# Altium schematic sheet Measurement.SchDoc(Measurement)
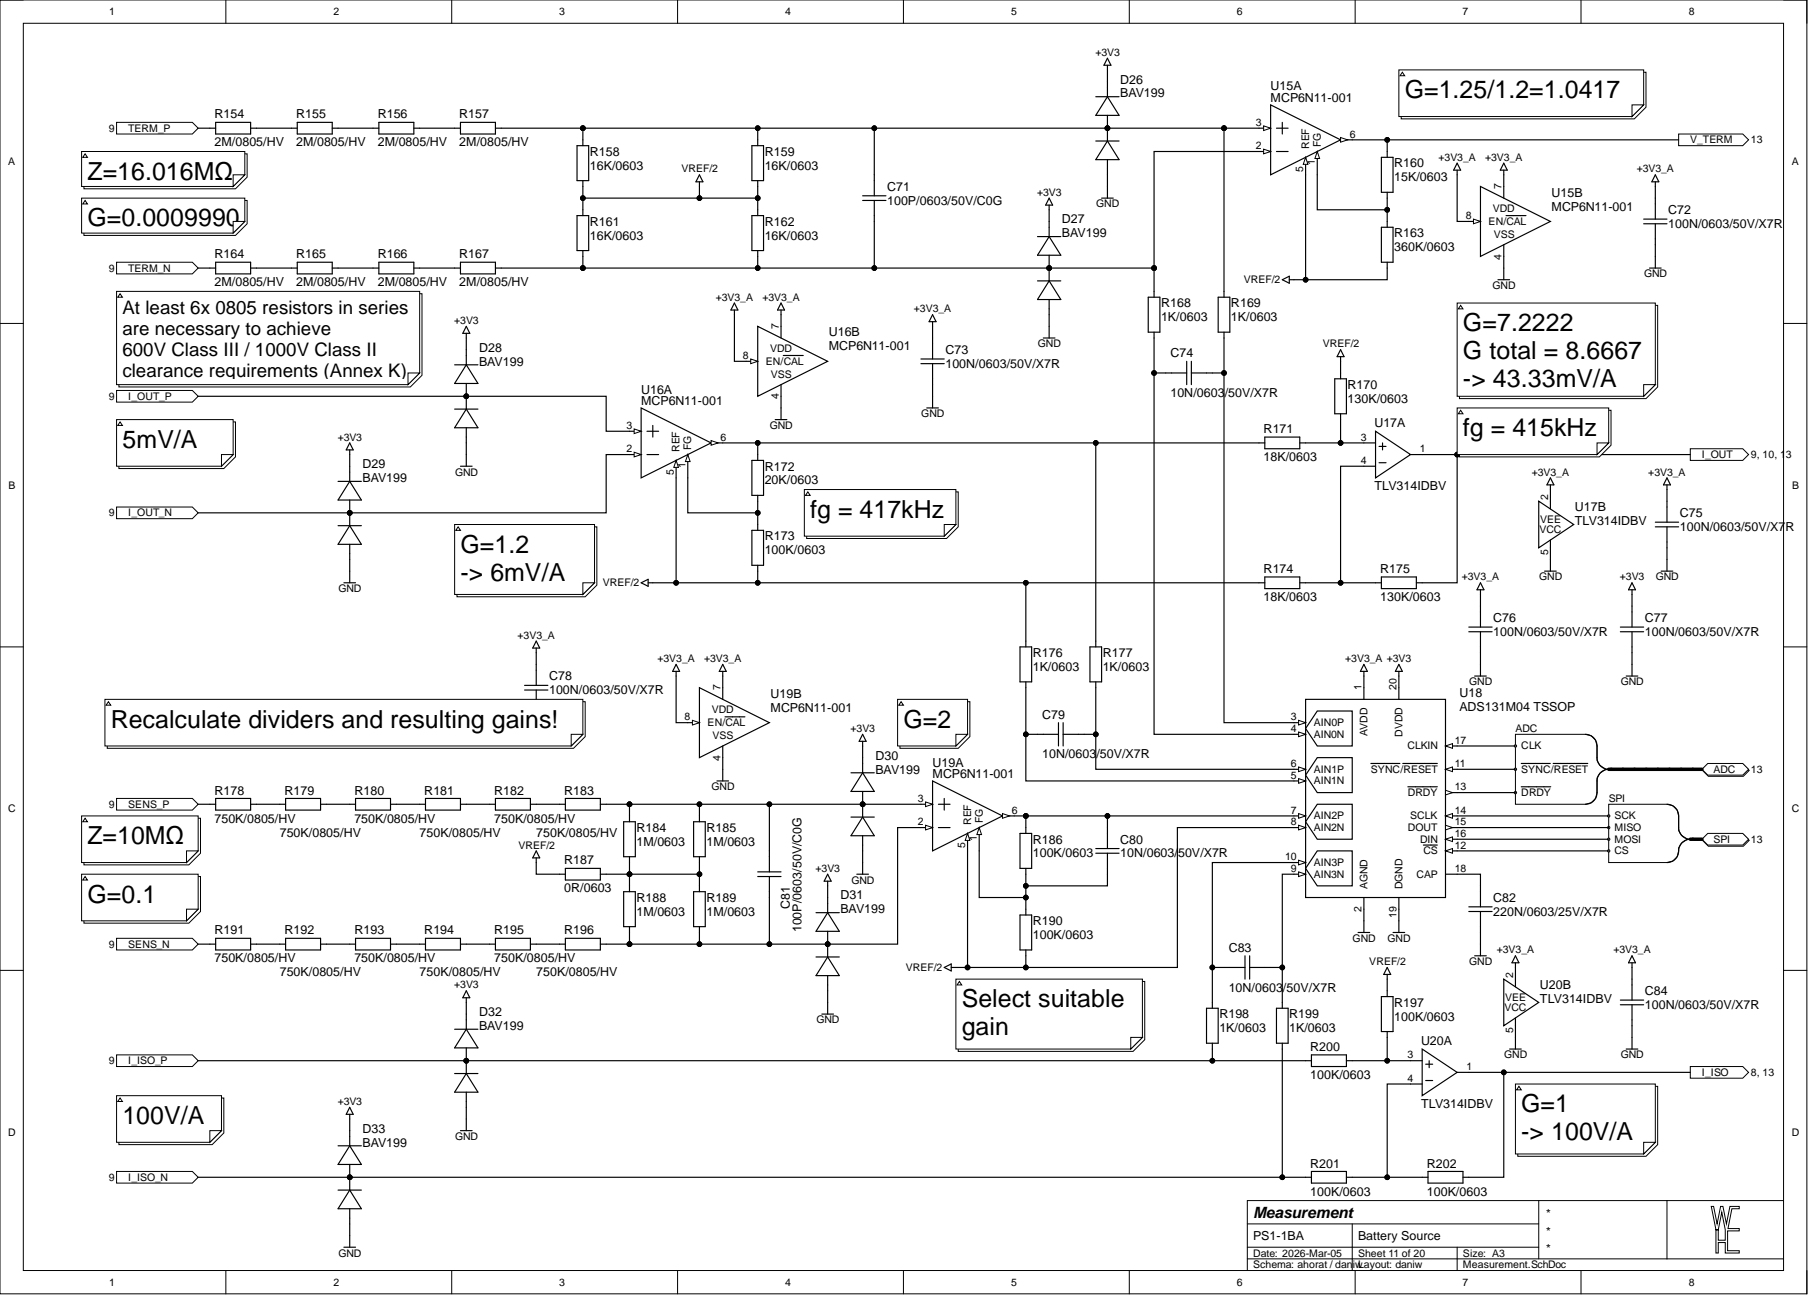
[diagram p0: Measurement.SchDoc]

## Parts
C71  100P/0603/50V/C0G  [CAP0603_C0G]
C72  100N/0603/50V/X7R  [CAP0603_X7R]
C73  100N/0603/50V/X7R  [CAP0603_X7R]
C74  10N/0603/50V/X7R  [CAP0603_X7R]
C75  100N/0603/50V/X7R  [CAP0603_X7R]
C76  100N/0603/50V/X7R  [CAP0603_X7R]
C77  100N/0603/50V/X7R  [CAP0603_X7R]
C78  100N/0603/50V/X7R  [CAP0603_X7R]
C79  10N/0603/50V/X7R  [CAP0603_X7R]
C80  10N/0603/50V/X7R  [CAP0603_X7R]
C81  100P/0603/50V/C0G  [CAP0603_C0G]
C82  220N/0603/25V/X7R  [CAP0603_X7R]
C83  10N/0603/50V/X7R  [CAP0603_X7R]
C84  100N/0603/50V/X7R  [CAP0603_X7R]
D26  BAV199  [SOT2395P280X125-3-SE]
D27  BAV199  [SOT2395P280X125-3-SE]
D28  BAV199  [SOT2395P280X125-3-SE]
D29  BAV199  [SOT2395P280X125-3-SE]
D30  BAV199  [SOT2395P280X125-3-SE]
D31  BAV199  [SOT2395P280X125-3-SE]
D32  BAV199  [SOT2395P280X125-3-SE]
D33  BAV199  [SOT2395P280X125-3-SE]
R154  2M/0805/HV  [R0805-0.50-HV-WW]
R155  2M/0805/HV  [R0805-0.50-HV-WW]
R156  2M/0805/HV  [R0805-0.50-HV-WW]
R157  2M/0805/HV  [R0805-0.50-HV-WW]
R158  16K/0603  [R0603-0.40-WW]
R159  16K/0603  [R0603-0.40-WW]
R160  15K/0603  [R0603-0.40-WW]
R161  16K/0603  [R0603-0.40-WW]
R162  16K/0603  [R0603-0.40-WW]
R163  360K/0603  [R0603-0.40-WW]
R164  2M/0805/HV  Package: 0805  [R0805-0.50-HV-WW]
R165  2M/0805/HV  [R0805-0.50-HV-WW]
R166  2M/0805/HV  [R0805-0.50-HV-WW]
R167  2M/0805/HV  [R0805-0.50-HV-WW]
R168  1K/0603  [R0603-0.40-WW]
R169  1K/0603  [R0603-0.40-WW]
R170  130K/0603  [R0603-0.40-WW]
R171  18K/0603  [R0603-0.40-WW]
R172  20K/0603  [R0603-0.40-WW]
R173  100K/0603  [R0603-0.40-WW]
R174  18K/0603  [R0603-0.40-WW]
R175  130K/0603  [R0603-0.40-WW]
R176  1K/0603  [R0603-0.40-WW]
R177  1K/0603  [R0603-0.40-WW]
R178  750K/0805/HV  [R0805-0.50-HV-WW]
R179  750K/0805/HV  [R0805-0.50-HV-WW]
R180  750K/0805/HV  [R0805-0.50-HV-WW]
R181  750K/0805/HV  [R0805-0.50-HV-WW]
R182  750K/0805/HV  [R0805-0.50-HV-WW]
R183  750K/0805/HV  [R0805-0.50-HV-WW]
R184  1M/0603  [R0603-0.40-WW]
R185  1M/0603  [R0603-0.40-WW]
R186  100K/0603  [R0603-0.40-WW]
R187  0R/0603  [R0603-0.40-WW]
R188  1M/0603  [R0603-0.40-WW]
R189  1M/0603  [R0603-0.40-WW]
R190  100K/0603  [R0603-0.40-WW]
R191  750K/0805/HV  [R0805-0.50-HV-WW]
R192  750K/0805/HV  [R0805-0.50-HV-WW]
R193  750K/0805/HV  [R0805-0.50-HV-WW]
R194  750K/0805/HV  [R0805-0.50-HV-WW]
R195  750K/0805/HV  [R0805-0.50-HV-WW]
R196  750K/0805/HV  [R0805-0.50-HV-WW]
R197  100K/0603  [R0603-0.40-WW]
R198  1K/0603  [R0603-0.40-WW]
R199  1K/0603  [R0603-0.40-WW]
R200  100K/0603  [R0603-0.40-WW]
R201  100K/0603  [R0603-0.40-WW]
R202  100K/0603  [R0603-0.40-WW]
U15  MCP6N11-001  [SOP127P600X155-8-SW]  (parts A,B)
U16  MCP6N11-001  [SOP127P600X155-8-SW]  (parts A,B)
U17  TLV314IDBV  [SOT2395P280X125-5-NW]  (parts A,B)
U18  ADS131M04 TSSOP  [SOP065P640X120-20NW]
U18
U19  MCP6N11-001  [SOP127P600X155-8-SW]  (parts A,B)
U20  TLV314IDBV  [SOT2395P280X125-5-NW]  (parts A,B)

## Ports
ADC, ADC.CLK, ADC.~{DRDY}, ADC.~{SYNC}/~{RESET}, I_ISO, I_ISO_N, I_ISO_P, I_OUT, I_OUT_N, I_OUT_P, SENS_N, SENS_P, SPI, SPI.CS, SPI.MISO, SPI.MOSI, SPI.SCK, TERM_N, TERM_P, V_TERM

## Nets
+3V3: C77.1 D26.2 D27.2 D28.2 D29.2 D30.2 D31.2 D32.2 D33.2 U18.20
+3V3_A: C72.1 C73.1 C75.1 C76.1 C78.1 C84.1 U15.7 U15.8(EN/CAL) U16.7 U16.8(EN/CAL) U17.2 U18.1 U19.7 U19.8(EN/CAL) U20.2
GND: C72.2 C73.2 C75.2 C76.2 C77.2 C78.2 C82.2 C84.2 D26.1 D27.1 D28.1 D29.1 D30.1 D31.1 D32.1 D33.1 U15.4 U16.4 U17.5 U18.2 U18.19 U19.4 U20.5
VREF/2: R158.2 R159.2 R161.1 R162.1 R163.2 R170.2 R173.2 R174.1 R176.1 R187.2 R190.2 R197.2 U15.5(REF) U16.5(REF) U18.8 U19.5(REF)
I_ISO: R202.2 U20.1 ; port I_ISO
I_ISO_N: D33.3 R199.2 R201.1 ; port I_ISO_N
I_ISO_P: D32.3 R198.2 R200.1 ; port I_ISO_P
I_OUT: R175.2 U17.1 ; port I_OUT
I_OUT_N: D29.3 U16.2 ; port I_OUT_N
I_OUT_P: D28.3 U16.3 ; port I_OUT_P
SENS_N: R191.1 ; port SENS_N
SENS_P: R178.1 ; port SENS_P
TERM_N: R164.1 ; port TERM_N
TERM_P: R154.1 ; port TERM_P
V_TERM: R160.1 U15.6 ; port V_TERM
NetC71_1: C71.1 D26.3 R157.1 R158.1 R159.1 R169.1 U15.3
NetC71_2: C71.2 D27.3 R161.2 R162.2 R167.2 R168.1 U15.2
NetC74_1: C74.1 R168.2 U18.4
NetC74_2: C74.2 R169.2 U18.3
NetC79_1: C79.1 R176.2 U18.5
NetC79_2: C79.2 R177.2 U18.6
NetC80_1: C80.1 R186.1 U18.7 U19.6
NetC80_2: C80.2 R186.2 R190.1 U19.1(FG)
NetC81_1: C81.1 D30.3 R183.2 R184.1 R185.1 U19.3
NetC81_2: C81.2 D31.3 R188.2 R189.2 R196.2 U19.2
NetC82_1: C82.1 U18.18
NetC83_1: C83.1 R198.1 U18.10
NetC83_2: C83.2 R199.1 U18.9
NetR154_2: R154.2 R155.2
NetR155_1: R155.1 R156.1
NetR156_2: R156.2 R157.2
NetR160_2: R160.2 R163.1 U15.1(FG)
NetR164_2: R164.2 R165.1
NetR165_2: R165.2 R166.1
NetR166_2: R166.2 R167.1
NetR170_1: R170.1 R171.2 U17.3
NetR171_1: R171.1 R172.1 R177.1 U16.6
NetR172_2: R172.2 R173.1 U16.1(FG)
NetR174_2: R174.2 R175.1 U17.4
NetR178_2: R178.2 R179.1
NetR179_2: R179.2 R180.1
NetR180_2: R180.2 R181.1
NetR181_2: R181.2 R182.1
NetR182_2: R182.2 R183.1
NetR184_2: R184.2 R185.2 R187.1 R188.1 R189.1
NetR191_2: R191.2 R192.1
NetR192_2: R192.2 R193.1
NetR193_2: R193.2 R194.1
NetR194_2: R194.2 R195.1
NetR195_2: R195.2 R196.1
NetR197_1: R197.1 R200.2 U20.3
NetR201_2: R201.2 R202.1 U20.4
NetU18_11: U18.11 ; port ADC
NetU18_12: U18.12 ; port SPI
NetU18_13: U18.13 ; port ADC
NetU18_14: U18.14 ; port SPI
NetU18_15: U18.15 ; port SPI
NetU18_16: U18.16 ; port SPI
NetU18_17: U18.17 ; port ADC

## Library data of the parts
C71 C81 = 100P/0603/50V/C0G: CAP SM 0603 100pF 50V C0G; Manufacturer: GENERIC; Manufacturer 1: YAGEO; Manufacturer Part Number 1: CC0603JRNPO9BN101; Absolute Value: 1E-10; Database Table Name: C$; Eurocircuits GPN: GPC0603101-C0G; Component Kind: Standard; HelpURL: https://www.yageo.com/upload/media/product/productsearch/datasheet/mlcc/UPY-GP_NP0_16V-to-50V_18.pdf; JLC Type: Basic; Manufacturer 2: YAGEO; Manufacturer Part Number 2: CC0603KRNPO9BN101; Manufacturer Part Number 3: CL10C101JB8NNNC; Package: 0603; Part Number: 100P/0603/50V/C0G; Supplier 1: Digi-Key; Supplier 2: Digi-Key; Supplier 3: LCSC; Supplier Part Number 1: 311-1069-1-ND; Supplier Part Number 2: 311-3600-1-ND; Supplier Part Number 3: C14858; Value: 100pF; Library Name: daniw_altium_lib.DbLib
C72 C73 C75-C78 C84 = 100N/0603/50V/X7R: CAP SM 0603 100nF 50V X7R; Manufacturer: GENERIC; Manufacturer 1: YAGEO; Manufacturer Part Number 1: CC0603KPX7R9BB104; Absolute Value: 1E-7; Database Table Name: C$; Eurocircuits GPN: GPC0603104; Component Kind: Standard; HelpURL: https://www.yageo.com/upload/media/product/app/datasheet/mlcc/upy-gphc_x7r_6_3v-to-250v.pdf; JLC Type: Basic; Manufacturer 2: YAGEO; Manufacturer Part Number 2: CC0603MRX7R9BB104; Manufacturer Part Number 3: CC0603KRX7R9BB104; Package: 0603; Part Number: 100N/0603/50V/X7R; Supplier 1: Digi-Key; Supplier 2: Digi-Key; Supplier 3: LCSC; Supplier Part Number 1: 311-3567-1-ND; Supplier Part Number 2: 311-4110-1-ND; Supplier Part Number 3: C14663; Value: 100nF; Library Name: daniw_altium_lib.DbLib
C74 C79 C80 C83 = 10N/0603/50V/X7R: CAP SM 0603 10nF 50V X7R; Manufacturer: GENERIC; Manufacturer 1: YAGEO; Manufacturer Part Number 1: CC0603KPX7R9BB103; Absolute Value: 1E-8; Database Table Name: C$; Eurocircuits GPN: GPC0603103; Component Kind: Standard; HelpURL: https://www.yageo.com/upload/media/product/app/datasheet/mlcc/upy-gphc_x7r_6_3v-to-250v.pdf; Manufacturer 2: YAGEO; Manufacturer Part Number 2: CC0603KRX7R9BB103; Manufacturer Part Number 3: CC0603KRX7R9BB103; Package: 0603; Part Number: 10N/0603/50V/X7R; Supplier 1: Digi-Key; Supplier 2: Digi-Key; Supplier 3: LCSC; Supplier Part Number 1: 311-1572-1-ND; Supplier Part Number 2: 311-1085-1-ND; Supplier Part Number 3: C100042; Value: 10nF; Library Name: daniw_altium_lib.DbLib
C82 = 220N/0603/25V/X7R: CAP SM 0603 220nF 25V X7R; Manufacturer: GENERIC; Absolute Value: 2.2E-7; Database Table Name: C$; Eurocircuits GPN: GPC0603; Component Kind: Standard; JLC Type: Basic; Manufacturer Part Number 3: CL10B224KA8NNNC; Package: 0603; Part Number: 220N/0603/25V/X7R; Supplier 3: LCSC; Supplier Part Number 3: C21120; Value: 220nF; Library Name: daniw_altium_lib.DbLib
D26-D33 = BAV199: Silicon Low Leakage Diode 85V; Manufacturer: Infineon Technologies; Manufacturer Part Number 1: BAV199E6433HTMA1; Database Table Name: D$; Absolute Value: 85V; Component Kind: Standard; HelpURL: https://www.infineon.com/dgdl/bav199series.pdf?folderId=db3a30431400ef6801141c748874044e&fileId=db3a30431400ef6801141cba733104e5; Manufacturer 1: Infineon Technologies; Manufacturer 3: LRC; Manufacturer Part Number 3: LBAV199LT1G; Package: SOT23; Part Number: BAV199; Supplier 1: Digikey; Supplier 3: LCSC; Supplier Part Number 1: BAV199E6433HTMA1CT-ND; Supplier Part Number 3: C12740; Value: BAV199; Library Name: daniw_altium_lib.DbLib
R154-R157 R164-R167 = 2M/0805/HV: RES SM 0805 2M High voltage; Manufacturer: GENERIC; Manufacturer 1: YAGEO; Manufacturer Part Number 1: RV0805FR-072ML; Absolute Value: 2000000; Database Table Name: R$; Component Kind: Standard; HelpURL: https://www.yageogroup.com/content/datasheet/asset/file/PYU-RV_51_ROHS_L; JLC Type: Extended; Manufacturer 3: YAGEO; Manufacturer Part Number 3: RV0805FR-072ML; Part Number: 2M/0805/HV; Supplier 1: Digi-Key; Supplier 3: LCSC; Supplier Part Number 1: 13-RV0805FR-072MLCT-ND; Supplier Part Number 3: C873372; Value: 2M; Library Name: daniw_altium_lib.DbLib
  R154-R157 R165-R167: Package: 0805
R158 R159 R161 R162 = 16K/0603: RES SM 0603 16K; Manufacturer: GENERIC; Manufacturer 1: YAGEO; Manufacturer Part Number 1: RC0603FR-1316KL; Absolute Value: 16000; Database Table Name: R$; Eurocircuits GPN: GPR060316K; Component Kind: Standard; HelpURL: https://www.yageogroup.com/content/datasheet/asset/file/PYU-RC_GROUP_51_ROHS_L; JLC Type: Extended; Manufacturer 2: YAGEO; Manufacturer 3: YAGEO; Manufacturer Part Number 2: RC0603FR-1016KL; Manufacturer Part Number 3: RC0603FR-0716KL; Package: 0603; Part Number: 16K/0603; Supplier 1: Digi-Key; Supplier 2: Digi-Key; Supplier 3: LCSC; Supplier Part Number 1: 13-RC0603FR-1316KLCT-ND; Supplier Part Number 2: 13-RC0603FR-1016KLCT-ND; Supplier Part Number 3: C137788; Value: 16K; Library Name: daniw_altium_lib.DbLib
R160 = 15K/0603: RES SM 0603 15K; Manufacturer: GENERIC; Manufacturer 1: YAGEO; Manufacturer Part Number 1: RC0603FR-1315KL; Absolute Value: 15000; Database Table Name: R$; Eurocircuits GPN: GPR060315K; Component Kind: Standard; HelpURL: https://www.yageogroup.com/content/datasheet/asset/file/PYU-RC_GROUP_51_ROHS_L; JLC Type: Basic; Manufacturer 2: YAGEO; Manufacturer 3: UNI-ROYAL(Uniroyal Elec); Manufacturer Part Number 2: RC0603FR-1015KL; Manufacturer Part Number 3: 0603WAF1502T5E; Package: 0603; Part Number: 15K/0603; Supplier 1: Digi-Key; Supplier 2: Digi-Key; Supplier 3: LCSC; Supplier Part Number 1: 13-RC0603FR-1315KLCT-ND; Supplier Part Number 2: 13-RC0603FR-1015KLCT-ND; Supplier Part Number 3: C22809; Value: 15K; Library Name: daniw_altium_lib.DbLib
R163 = 360K/0603: RES SM 0603 360K; Manufacturer: GENERIC; Manufacturer 1: YAGEO; Manufacturer Part Number 1: RC0603FR-07360KL; Absolute Value: 360000; Database Table Name: R$; Eurocircuits GPN: GPR0603360K; Component Kind: Standard; HelpURL: https://www.yageogroup.com/content/datasheet/asset/file/PYU-RC_GROUP_51_ROHS_L; JLC Type: Extended; Manufacturer 3: UNI-ROYAL(Uniroyal Elec); Manufacturer Part Number 3: 0603WAF3603T5E; Package: 0603; Part Number: 360K/0603; Supplier 1: Digi-Key; Supplier 3: LCSC; Supplier Part Number 1: 311-360KHRCT-ND; Supplier Part Number 3: C23146; Value: 360K; Library Name: daniw_altium_lib.DbLib
R168 R169 R176 R177 R198 R199 = 1K/0603: RES SM 0603 1K; Manufacturer: GENERIC; Manufacturer 1: YAGEO; Manufacturer Part Number 1: RC0603FR-131KL; Absolute Value: 1000; Database Table Name: R$; Eurocircuits GPN: GPR06031K; Component Kind: Standard; HelpURL: https://www.yageogroup.com/content/datasheet/asset/file/PYU-RC_GROUP_51_ROHS_L; JLC Type: Basic; Manufacturer 2: YAGEO; Manufacturer 3: UNI-ROYAL(Uniroyal Elec); Manufacturer Part Number 2: RC0603FR-101KL; Manufacturer Part Number 3: 0603WAF1001T5E; Package: 0603; Part Number: 1K/0603; Supplier 1: Digi-Key; Supplier 2: Digi-Key; Supplier 3: LCSC; Supplier Part Number 1: 13-RC0603FR-131KLCT-ND; Supplier Part Number 2: 13-RC0603FR-101KLCT-ND; Supplier Part Number 3: C21190; Value: 1K; Library Name: daniw_altium_lib.DbLib
R170 R175 = 130K/0603: RES SM 0603 130K; Manufacturer: GENERIC; Manufacturer 1: YAGEO; Manufacturer Part Number 1: RC0603FR-07130KL; Absolute Value: 130000; Database Table Name: R$; Eurocircuits GPN: GPR0603130K; Component Kind: Standard; HelpURL: https://www.yageogroup.com/content/datasheet/asset/file/PYU-RC_GROUP_51_ROHS_L; JLC Type: Extended; Manufacturer 3: YAGEO; Manufacturer Part Number 3: RC0603FR-07130KL; Package: 0603; Part Number: 130K/0603; Supplier 1: Digi-Key; Supplier 3: LCSC; Supplier Part Number 1: 311-130KHRCT-ND; Supplier Part Number 3: C163432; Value: 130K; Library Name: daniw_altium_lib.DbLib
R171 R174 = 18K/0603: RES SM 0603 18K; Manufacturer: GENERIC; Manufacturer 1: YAGEO; Manufacturer Part Number 1: RC0603FR-1318KL; Absolute Value: 18000; Database Table Name: R$; Eurocircuits GPN: GPR060318K; Component Kind: Standard; HelpURL: https://www.yageogroup.com/content/datasheet/asset/file/PYU-RC_GROUP_51_ROHS_L; JLC Type: Basic; Manufacturer 2: YAGEO; Manufacturer 3: UNI-ROYAL; Manufacturer Part Number 2: RC0603FR-1018KL; Manufacturer Part Number 3: 0603WAF1802T5E; Package: 0603; Part Number: 18K/0603; Supplier 1: Digi-Key; Supplier 2: Digi-Key; Supplier 3: LCSC; Supplier Part Number 1: 13-RC0603FR-1318KLCT-ND; Supplier Part Number 2: 13-RC0603FR-1018KLCT-ND; Supplier Part Number 3: C25810; Value: 18K; Library Name: daniw_altium_lib.DbLib
R172 = 20K/0603: RES SM 0603 20K; Manufacturer: GENERIC; Manufacturer 1: YAGEO; Manufacturer Part Number 1: RC0603FR-1320KL; Absolute Value: 20000; Database Table Name: R$; Eurocircuits GPN: GPR060320K; Component Kind: Standard; HelpURL: https://www.yageogroup.com/content/datasheet/asset/file/PYU-RC_GROUP_51_ROHS_L; JLC Type: Basic; Manufacturer 2: YAGEO; Manufacturer 3: UNI-ROYAL(Uniroyal Elec); Manufacturer Part Number 2: RC0603FR-1020KL; Manufacturer Part Number 3: 0603WAF2002T5E; Package: 0603; Part Number: 20K/0603; Supplier 1: Digi-Key; Supplier 2: Digi-Key; Supplier 3: LCSC; Supplier Part Number 1: 13-RC0603FR-1320KLCT-ND; Supplier Part Number 2: 13-RC0603FR-1020KLCT-ND; Supplier Part Number 3: C4184; Value: 20K; Library Name: daniw_altium_lib.DbLib
R173 R186 R190 R197 R200-R202 = 100K/0603: RES SM 0603 100K; Manufacturer: GENERIC; Manufacturer 1: YAGEO; Manufacturer Part Number 1: RC0603FR-13100KL; Absolute Value: 100000; Database Table Name: R$; Eurocircuits GPN: GPR0603100K; Component Kind: Standard; HelpURL: https://www.yageogroup.com/content/datasheet/asset/file/PYU-RC_GROUP_51_ROHS_L; JLC Type: Basic; Manufacturer 2: YAGEO; Manufacturer 3: UNI-ROYAL(Uniroyal Elec); Manufacturer Part Number 2: RC0603FR-10100KL; Manufacturer Part Number 3: 0603WAF1003T5E; Package: 0603; Part Number: 100K/0603; Supplier 1: Digi-Key; Supplier 2: Digi-Key; Supplier 3: LCSC; Supplier Part Number 1: 13-RC0603FR-13100KLCT-ND; Supplier Part Number 2: 13-RC0603FR-10100KLCT-ND; Supplier Part Number 3: C25803; Value: 100K; Library Name: daniw_altium_lib.DbLib
R178-R183 R191-R196 = 750K/0805/HV: RES SM 0805 750K High voltage; Manufacturer: GENERIC; Manufacturer 1: YAGEO; Absolute Value: 750000; Database Table Name: R$; Component Kind: Standard; HelpURL: https://www.yageogroup.com/content/datasheet/asset/file/PYU-RV_51_ROHS_L; JLC Type: Extended; Manufacturer 3: YAGEO; Manufacturer Part Number 3: RV0805FR-07750KL; Package: 0805; Part Number: 750K/0805/HV; Supplier 3: LCSC; Supplier Part Number 3: C873432; Value: 750K; Library Name: daniw_altium_lib.DbLib
R184 R185 R188 R189 = 1M/0603: RES SM 0603 1M; Manufacturer: GENERIC; Manufacturer 1: YAGEO; Manufacturer Part Number 1: RC0603FR-131ML; Absolute Value: 1000000; Database Table Name: R$; Eurocircuits GPN: GPR06031M; Component Kind: Standard; HelpURL: https://www.yageogroup.com/content/datasheet/asset/file/PYU-RC_GROUP_51_ROHS_L; JLC Type: Basic; Manufacturer 2: YAGEO; Manufacturer 3: UNI-ROYAL(Uniroyal Elec); Manufacturer Part Number 2: RC0603FR-101ML; Manufacturer Part Number 3: 0603WAF1004T5E; Package: 0603; Part Number: 1M/0603; Supplier 1: Digi-Key; Supplier 2: Digi-Key; Supplier 3: LCSC; Supplier Part Number 1: 13-RC0603FR-131MLCT-ND; Supplier Part Number 2: 13-RC0603FR-101MLCT-ND; Supplier Part Number 3: C22935; Value: 1M; Library Name: daniw_altium_lib.DbLib
R187 = 0R/0603: RES SM 0603 0R; Manufacturer: GENERIC; Manufacturer 1: YAGEO; Manufacturer Part Number 1: RC0603FR-070RL; Absolute Value: 0; Database Table Name: R$; Eurocircuits GPN: GPR06030R; Component Kind: Standard; HelpURL: https://www.yageogroup.com/content/datasheet/asset/file/PYU-RC_GROUP_51_ROHS_L; JLC Type: Basic; Manufacturer 2: YAGEO; Manufacturer 3: UNI-ROYAL(Uniroyal Elec); Manufacturer Part Number 2: RC0603FR-130RL; Manufacturer Part Number 3: 0603WAF0000T5E; Package: 0603; Part Number: 0R/0603; Supplier 1: Digi-Key; Supplier 2: Digi-Key; Supplier 3: LCSC; Supplier Part Number 1: 311-0.0HRCT-ND; Supplier Part Number 2: 13-RC0603FR-130RLCT-ND; Supplier Part Number 3: C21189; Value: 0R; Library Name: daniw_altium_lib.DbLib
U15 U16 U19 = MCP6N11-001 SO-8: MCP6N11-001 500 kHz, 800 μA Instrumentation Amplifier SO-8; Manufacturer: Microchip Technology; Manufacturer Part Number 1: MCP6N11-001E/SN; Database Table Name: Integrated$; Component Kind: Standard; HelpURL: https://ww1.microchip.com/downloads/en/DeviceDoc/25073A.pdf; Manufacturer 1: Microchip Technology; Manufacturer 2: Microchip Technology; Manufacturer 3: Microchip Tech; Manufacturer Part Number 2: MCP6N11T-001E/SN; Manufacturer Part Number 3: MCP6N11T-001E/SN; Package: SO-8; Part Number: MCP6N11-001 SO-8; Supplier 1: Digi-Key; Supplier 2: Digi-Key; Supplier 3: LCSC; Supplier Part Number 1: MCP6N11-001E/SN-ND; Supplier Part Number 2: MCP6N11T-001E/SN-ND; Supplier Part Number 3: C623787; Library Name: daniw_altium_lib.DbLib
U17 U20 = TLV314 SOT23: TLV314, 3-MHz, Low-Power, Internal EMI Filter, RRIO, Operational Amplifier; Manufacturer: Texas Instruments; Manufacturer Part Number 1: TLV314IDBVR; Database Table Name: Integrated$; Component Kind: Standard; HelpURL: https://www.ti.com/lit/ds/symlink/tlv4314.pdf; Manufacturer 1: Texas Instruments; Manufacturer 2: Texas Instruments; Manufacturer 3: Texas Instruments; Manufacturer Part Number 2: TLV314IDBVT; Manufacturer Part Number 3: TLV314IDBVR; Package: SOT23-5; Part Number: TLV314 SOT23; Supplier 1: Digi-Key; Supplier 2: Digi-Key; Supplier 3: LCSC; Supplier Part Number 1: 296-46275-1-ND; Supplier Part Number 2: 296-44110-2-ND; Supplier Part Number 3: C133032; Library Name: daniw_altium_lib.DbLib
U18 = ADS131M04 TSSOP: ADS131M04 4-Channel, Simultaneously-Sampling, 24-Bit, Delta-Sigma ADC; Manufacturer: Texas Instruments; Manufacturer Part Number 1: ADS131M04IPWR; Database Table Name: Integrated$; Component Kind: Standard; HelpURL: https://www.ti.com/lit/ds/symlink/ads131m04.pdf; Manufacturer 1: Texas Instruments; Manufacturer 2: Texas Instruments; Manufacturer 3: Texas Instruments; Manufacturer Part Number 2: ADS131M04IPWT; Manufacturer Part Number 3: ADS131M04IPWR; Package: TSSOP-20; Part Number: ADS131M04 TSSOP; Supplier 1: Digi-Key; Supplier 2: Digi-Key; Supplier 3: LCSC; Supplier Part Number 1: 296-ADS131M04IPWRCT-ND; Supplier Part Number 2: 296-ADS131M04IPWTCT-ND; Supplier Part Number 3: C2862562; Library Name: daniw_altium_lib.DbLib
